# Altium schematic sheet OvertheTOP_Sensors.SchDoc
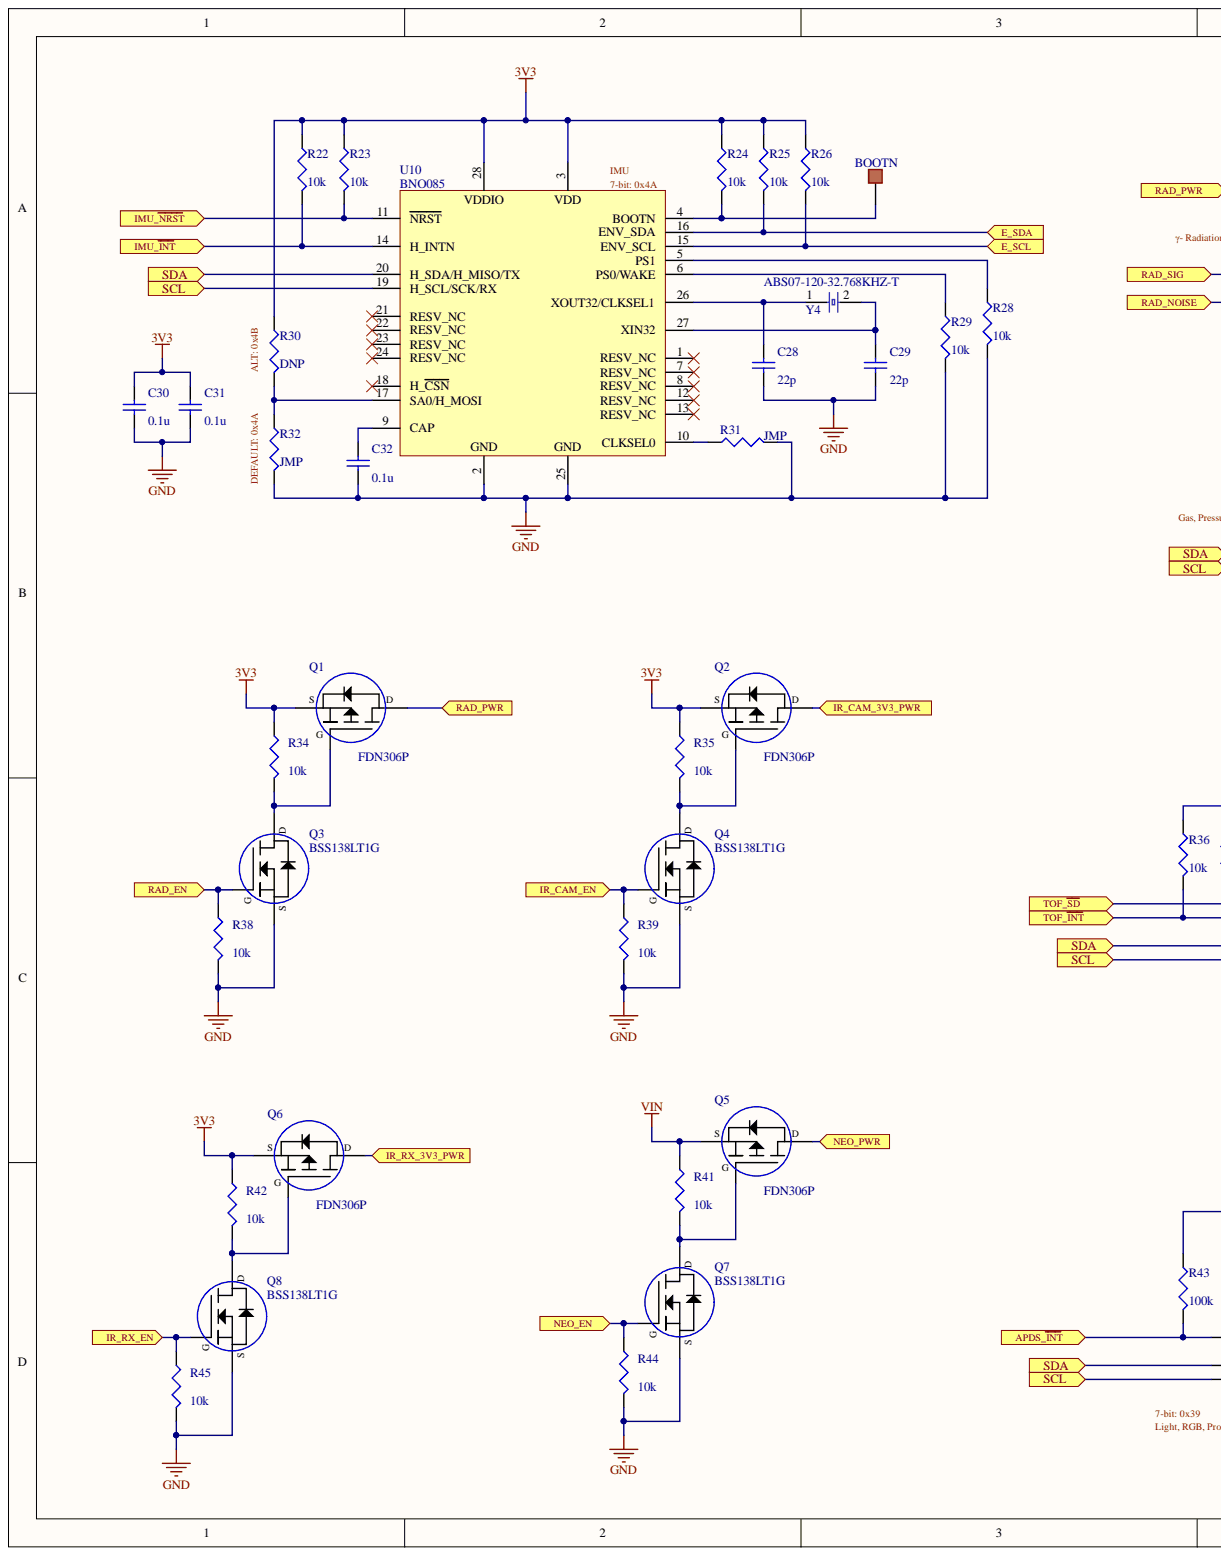
[diagram p0: OvertheTOP_Sensors.SchDoc, left]
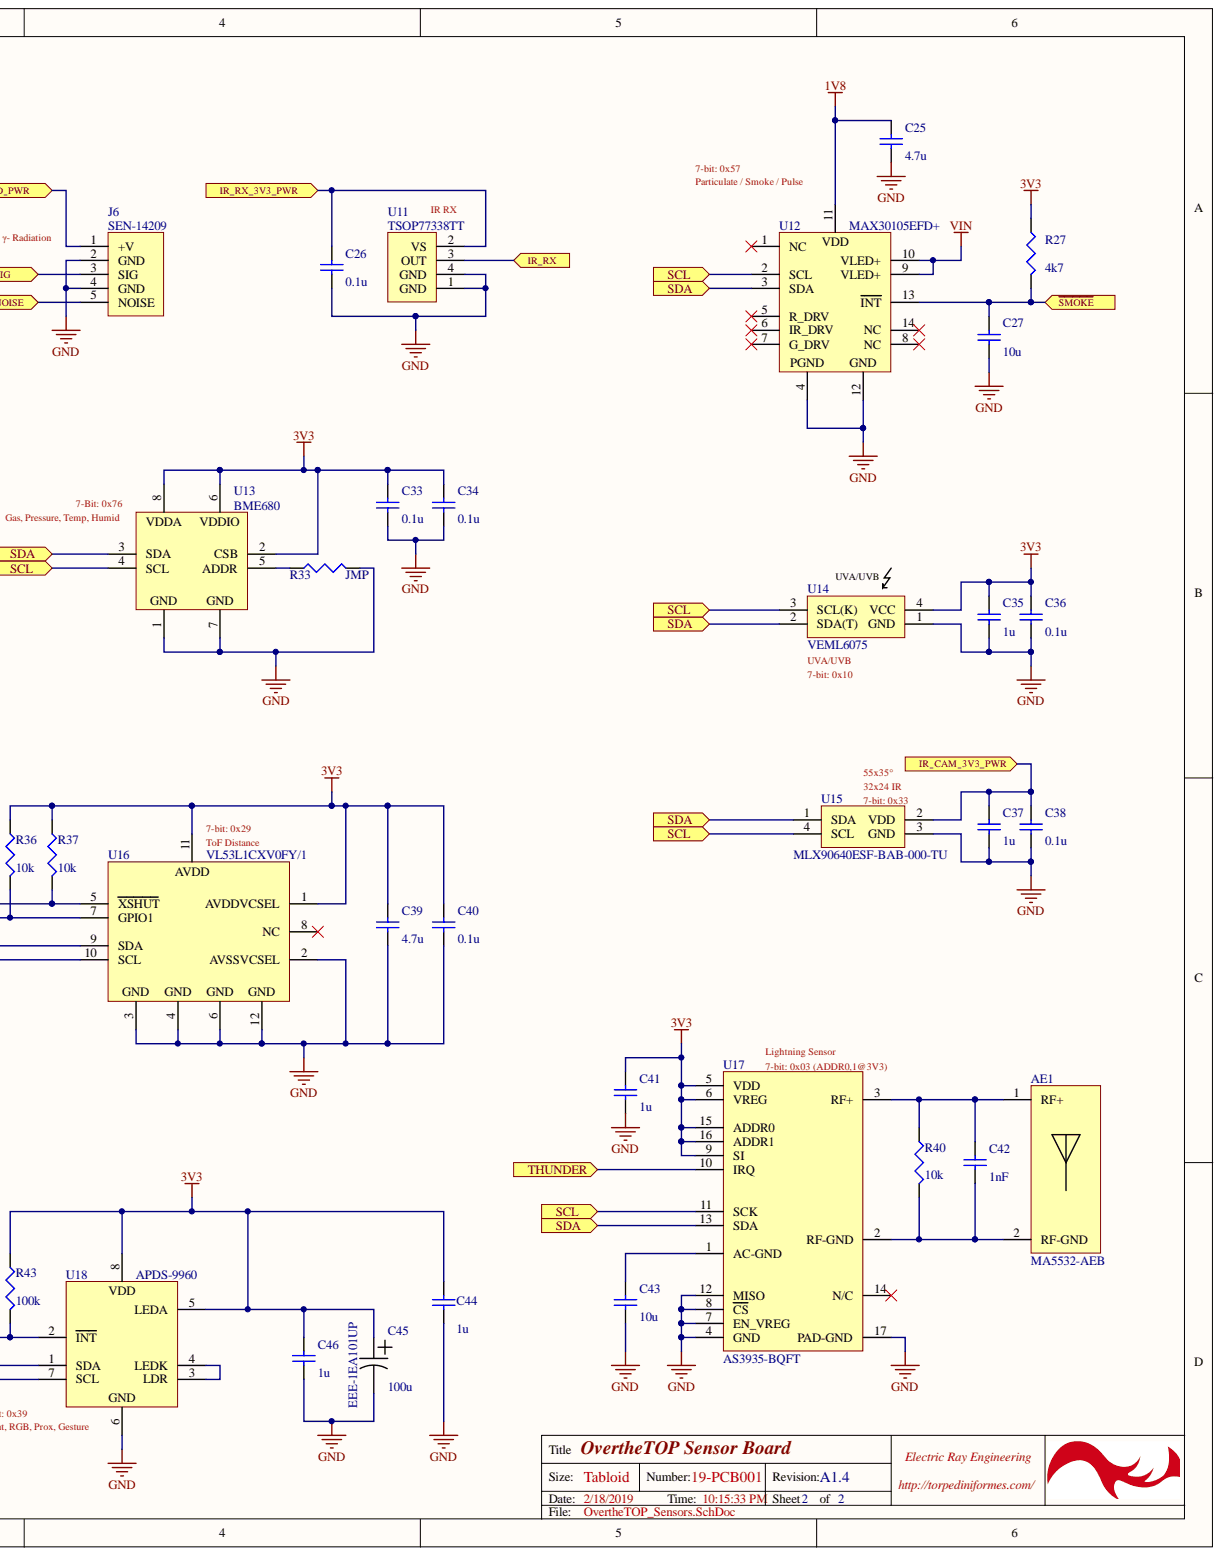
[diagram p1: OvertheTOP_Sensors.SchDoc, right]

## Parts
AE1  MA5532-AEB  [MA5532]
BOOTN  1.5mm  [TP_1.5mm_Square_Bare]  (value not drawn)
C25  value 4.7u  [6-0805_L]  (hidden comment: Cap)
C26  value 0.1u  [6-0805_L]  (hidden comment: Cap)
C27  value 10u  [6-0805_L]  (hidden comment: Cap)
C28  value 22p  [6-0805_L]  (hidden comment: Cap)
C29  value 22p  [6-0805_L]  (hidden comment: Cap)
C30  value 0.1u  [6-0805_L]  (hidden comment: Cap)
C31  value 0.1u  [6-0805_L]  (hidden comment: Cap)
C32  value 0.1u  [6-0805_L]  (hidden comment: Cap)
C33  value 0.1u  [6-0805_L]  (hidden comment: Cap)
C34  value 0.1u  [6-0805_L]  (hidden comment: Cap)
C35  value 1u  [6-0805_L]  (hidden comment: Cap)
C36  value 0.1u  [6-0805_L]  (hidden comment: Cap)
C37  value 1u  [6-0805_L]  (hidden comment: Cap)
C38  value 0.1u  [6-0805_L]  (hidden comment: Cap)
C39  value 4.7u  [6-0805_L]  (hidden comment: Cap)
C40  value 0.1u  [6-0805_L]  (hidden comment: Cap)
C41  value 1u  [6-0805_L]  (hidden comment: Cap)
C42  value 1nF  [0603]  (hidden comment: Cap)
C43  value 10u  [6-0805_L]  (hidden comment: Cap)
C44  value 1u  [6-0805_L]  (hidden comment: Cap)
C45  EEE-1EA101UP  value 100u  [CAP_SIZE_E_PANA]
C46  value 1u  [6-0805_L]  (hidden comment: Cap)
J6  SEN-14209  [SEN-14209]
Q1  FDN306P  [SuperSOT-3]
Q2  FDN306P  [SuperSOT-3]
Q3  BSS138LT1G  [SOT-23]
Q4  BSS138LT1G  [SOT-23]
Q5  FDN306P  [SuperSOT-3]
Q6  FDN306P  [SuperSOT-3]
Q7  BSS138LT1G  [SOT-23]
Q8  BSS138LT1G  [SOT-23]
R22  value 10k  [J1-0603]  (hidden comment: Res3)
R23  value 10k  [J1-0603]  (hidden comment: Res3)
R24  value 10k  [J1-0603]  (hidden comment: Res3)
R25  value 10k  [J1-0603]  (hidden comment: Res3)
R26  value 10k  [J1-0603]  (hidden comment: Res3)
R27  value 4k7  [J1-0603]  (hidden comment: Res3)
R28  value 10k  [J1-0603]  (hidden comment: Res3)
R29  value 10k  [J1-0603]  (hidden comment: Res3)
R30  value DNP  [J1-0603]  (hidden comment: Res3)
R31  value JMP  [J1-0603]  (hidden comment: Res3)
R32  value JMP  [J1-0603]  (hidden comment: Res3)
R33  value JMP  [J1-0603]  (hidden comment: Res3)
R34  value 10k  [J1-0603]  (hidden comment: Res3)
R35  value 10k  [J1-0603]  (hidden comment: Res3)
R36  value 10k  [J1-0603]  (hidden comment: Res3)
R37  value 10k  [J1-0603]  (hidden comment: Res3)
R38  value 10k  [J1-0603]  (hidden comment: Res3)
R39  value 10k  [J1-0603]  (hidden comment: Res3)
R40  value 10k  [J1-0603]  (hidden comment: Res3)
R41  value 10k  [J1-0603]  (hidden comment: Res3)
R42  value 10k  [J1-0603]  (hidden comment: Res3)
R43  value 100k  [J1-0603]  (hidden comment: Res3)
R44  value 10k  [J1-0603]  (hidden comment: Res3)
R45  value 10k  [J1-0603]  (hidden comment: Res3)
U10  BNO085  [BNO085]
U11  TSOP77338TT  [TSOP77338TT]
U12  MAX30105EFD+  [OLGA-14_MAX30105]
U13  BME680  [BME680]
U14  VEML6075  [VEML6075]
U15  MLX90640ESF-BAB-000-TU  [MLX90640]
U16  VL53L1CXV0FY/1  [VL53L1X]
U17  AS3935-BQFT  [AS3935]
U18  APDS-9960  [APDS-9960]
Y4  ABS07-120-32.768KHZ-T  [XTAL_32x15_BSB]

## Ports
APDS_~{INT}, E_SCL, E_SDA, IMU_~{INT}, IMU_~{NRST}, IR_CAM_3V3_PWR, IR_CAM_EN, IR_RX, IR_RX_3V3_PWR, IR_RX_EN, NEO_EN, NEO_PWR, RAD_EN, RAD_NOISE, RAD_PWR, RAD_SIG, SCL, SDA, THUNDER, TOF_~{INT}, TOF_~{SD}, ~{SMOKE}

## Nets
1V8: C25.2 U12.11
3V3: C30.1 C31.1 C33.2 C34.2 C35.2 C36.2 C39.2 C40.2 C41.2 C44.2 C45.P C46.2 Q1.2 Q2.2 Q6.2 R22.1 R23.1 R24.1 R25.1 R26.1 R27.1 R30.1 R34.1 R35.1 R36.1 R37.1 R42.1 R43.1 U10.3 U10.28 U13.2(CSB) U13.6 U13.8 U14.4(VCC) U16.1(AVDDVCSEL) U16.11 U17.5(VDD) U17.6(VREG) U17.9(SI) U17.15(ADDR0) U17.16(ADDR1) U18.5(LEDA) U18.8
GND: C25.1 C26.1 C27.1 C28.2 C29.2 C30.2 C31.2 C32.2 C33.1 C34.1 C35.1 C36.1 C37.1 C38.1 C39.1 C40.1 C41.1 C43.1 C44.1 C45.N C46.1 J6.2(GND) J6.4(GND) Q3.2 Q4.2 Q7.2 Q8.2 R28.2 R29.2 R31.2 R32.2 R33.2 R38.2 R39.2 R44.2 R45.2 U10.2 U10.25 U11.1(GND) U11.4(GND) U12.4 U12.12 U13.1 U13.7 U14.1(GND) U15.3(GND) U16.2(AVSSVCSEL) U16.3 U16.4 U16.6 U16.12 U17.4(GND) U17.7(EN_VREG) U17.8(CS) U17.12(MISO) U17.17(PAD-GND) U18.6
VIN: Q5.2 R41.1 U12.9(VLED+) U12.10(VLED+)
APDS_~{INT}: R43.2 U18.2(INT) ; port APDS_~{INT}
E_SCL: R26.2 U10.15(ENV_SCL) ; port E_SCL
E_SDA: R25.2 U10.16(ENV_SDA) ; port E_SDA
IMU_~{INT}: R22.2 U10.14(H_INTN) ; port IMU_~{INT}
IMU_~{NRST}: R23.2 U10.11(NRST) ; port IMU_~{NRST}
IR_CAM_3V3_PWR: C37.2 C38.2 Q2.3 U15.2(VDD) ; port IR_CAM_3V3_PWR
IR_CAM_EN: Q4.1 R39.1 ; port IR_CAM_EN
IR_RX: U11.3(OUT) ; port IR_RX
IR_RX_3V3_PWR: C26.2 Q6.3 U11.2(VS) ; port IR_RX_3V3_PWR
IR_RX_EN: Q8.1 R45.1 ; port IR_RX_EN
NEO_EN: Q7.1 R44.1 ; port NEO_EN
NEO_PWR: Q5.3 ; port NEO_PWR
RAD_EN: Q3.1 R38.1 ; port RAD_EN
RAD_NOISE: J6.5(NOISE) ; port RAD_NOISE
RAD_PWR: J6.1(+V) Q1.3 ; port RAD_PWR
RAD_SIG: J6.3(SIG) ; port RAD_SIG
~{SMOKE}: C27.2 R27.2 U12.13(INT) ; port ~{SMOKE}
SCL: U10.19(H_SCL/SCK/RX) U12.2(SCL) U13.4(SCL) U14.3(SCL(K)) U15.4(SCL) U16.10(SCL) U17.11(SCK) U18.7(SCL) ; port SCL
SDA: U10.20(H_SDA/H_MISO/TX) U12.3(SDA) U13.3(SDA) U14.2(SDA(T)) U15.1(SDA) U16.9(SDA) U17.13(SDA) U18.1(SDA) ; port SDA
THUNDER: U17.10(IRQ) ; port THUNDER
TOF_~{INT}: R36.2 U16.7(GPIO1) ; port TOF_~{INT}
TOF_~{SD}: R37.2 U16.5(XSHUT) ; port TOF_~{SD}
NetAE1_1: AE1.1 C42.1 R40.1 U17.3(RF+)
NetAE1_2: AE1.2 C42.2 R40.2 U17.2(RF-GND)
NetBOOTN_1: BOOTN.1 R24.2 U10.4(BOOTN)
NetC28_1: C28.1 U10.26(XOUT32/CLKSEL1) Y4.1
NetC29_1: C29.1 U10.27(XIN32) Y4.2
NetC32_1: C32.1 U10.9(CAP)
NetC43_2: C43.2 U17.1(AC-GND)
NetQ1_1: Q1.1 Q3.3 R34.2
NetQ2_1: Q2.1 Q4.3 R35.2
NetQ5_1: Q5.1 Q7.3 R41.2
NetQ6_1: Q6.1 Q8.3 R42.2
NetR28_1: R28.1 U10.5(PS1)
NetR29_1: R29.1 U10.6(PS0/WAKE)
NetR30_2: R30.2 R32.1 U10.17(SA0/H_MOSI)
NetR31_1: R31.1 U10.10(CLKSEL0)
NetR33_1: R33.1 U13.5(ADDR)
NetU18_3: U18.3(LDR) U18.4(LEDK)

Not connected: U10 1(RESV_NC) 7(RESV_NC) 8(RESV_NC) 12(RESV_NC) 13(RESV_NC) 18(H_CSN) 21(RESV_NC) 22(RESV_NC) 23(RESV_NC) 24(RESV_NC); U12 1(NC) 5(R_DRV) 6(IR_DRV) 7(G_DRV) 8(NC) 14(NC); U16 8(NC); U17 14(N/C)

## Library data of the parts
AE1 = MA5532-AE: RFID Transponders; Manufacturer: Coilcraft; Component Kind: Standard; Manufacturer Part Number: MA5532-AEB; Library Name: Electric_Ray_Integrated_01SEPT2018.IntLib
BOOTN = TestPoint_Solderable_1.5mm_Bare: Testpoint Solderable 1.5mm Square; Component Kind: Standard; Library Name: Electric_Ray_Integrated_01SEPT2018.IntLib
C25-C44 C46 = Cap: Capacitor; Component Kind: Standard; LatestRevisionDate: 17-Jul-2002; LatestRevisionNote: Re-released for DXP Platform.; PackageDescription: Radial Cap, Thru-Hole; 2 Leads; 0.3 in Pin Spacing; PackageReference: RAD-0.3; Published: 8-Jun-2000; Simulation: CAP; Library Name: Electric_Ray_Integrated_01SEPT2018.IntLib; Publisher: Altium Limited
C45 = EEE-1EA101UP: CAP ALUM 100UF 20% 25V SMD; Manufacturer: Panasonic Electronic Components; Component Kind: Standard; Manufacturer Part Number: EEE-1EA101UP; Library Name: Electric_Ray_Integrated_01SEPT2018.IntLib
J6 = SEN-14209: Pocket Geiger Radiation Sensor - Type 5; Manufacturer: Sparkfun; Component Kind: Standard; Manufacturer Part Number: SEN-14209; Library Name: Electric_Ray_Integrated_01SEPT2018.IntLib
Q1 Q2 Q5 Q6 = FDN306P: P-Channel -1.8V Specified PowerTrench MOSFET; Manufacturer: On Semiconductor / Fairchild; Component Kind: Standard; Manufacturer Part Number: FDN306P; Library Name: Electric_Ray_Integrated_01SEPT2018.IntLib
Q3 Q4 Q7 Q8 = BSS138LT1G: Power MOSFET, 200mA, 50V, N-Channel, SOT-23; Manufacturer: On Semiconductor; Component Kind: Standard; Manufacturer Part Number: BSS138LT1G; Library Name: Electric_Ray_Integrated_01SEPT2018.IntLib
R22-R45 = Res3: Resistor; Component Kind: Standard; LatestRevisionDate: 29-May-2009; LatestRevisionNote: IPC-7351 Footprint Added.; Library Name: Miscellaneous Devices.IntLib; PackageDescription: Chip Resistor; PackageReference: J1-0603; Published: 8-Jun-2000; Simulation: RESISTOR; Publisher: Altium Limited
U10 = BNO085: IMU ACCEL/GYRO/MAG I2C 32BIT; Manufacturer: Hillcrest Laboratories, Inc.; Component Kind: Standard; Manufacturer Part Number: BNO085; Library Name: ERI_01SEPT2018.SchLib
U11 = TSOP77338TT: MOD IR RCVR 38KHZ TOP VIEW; Manufacturer: Vishay Semiconductor Opto Division; Component Kind: Standard; Manufacturer Part Number: TSOP77338TT; Library Name: Electric_Ray_Integrated_01SEPT2018.IntLib
U12 = MAX30105: SENSOR OPTIC AIR QUAL 14-OESIP; Manufacturer: Maxim Integrated; Component Kind: Standard; Manufacturer Part Number: MAX30105EFD+; Library Name: ERI_01SEPT2018.SchLib
U13 = BME680: SENSOR RH PRESSURE TEMP VOC; Manufacturer: Bosch Sensortec; Component Kind: Standard; Manufacturer Part Number: BME680; Library Name: Electric_Ray_Integrated_01SEPT2018.IntLib
U14 = VEML6075: UVA/UVB SENSOR W/I2C 4-OPLGA; Manufacturer: Vishay Semiconductor Opto Division; Component Kind: Standard; Manufacturer Part Number: VEML6075; Library Name: Electric_Ray_Integrated_01SEPT2018.IntLib
U15 = MLX90640: SENSOR DGTL -40C-85C TO39; Manufacturer: Melexis Technologies NV; Component Kind: Standard; Manufacturer Part Number: MLX90640ESF-BAB-000-TU; Library Name: Electric_Ray_Integrated_01SEPT2018.IntLib
U16 = VL53L1X: OPTICAL LGA12 4.9X2; Manufacturer: STMicroelectronics; Component Kind: Standard; Manufacturer Part Number: VL53L1CXV0FY/1; Library Name: Electric_Ray_Integrated_01SEPT2018.IntLib
U17 = AS3935: IC SENSOR LIGHTNING MLPQ-16; Manufacturer: ams; Component Kind: Standard; Manufacturer Part Number: AS3935-BQFT; Library Name: ERI_01SEPT2018.SchLib
U18 = APDS-9960: SENSOR AMBIENT/PROX DGTL; Manufacturer: Broadcom Limited; Component Kind: Standard; Manufacturer Part Number: APDS-9960; Library Name: ERI_01SEPT2018.SchLib
Y4 = ABS07-120-32.768KHZ-T: CRYSTAL 32.7680KHZ 6PF SMD; Manufacturer: Abracon LLC; Component Kind: Standard; Manufacturer Part Number: ABS07-120-32.768KHZ-T; Library Name: Electric_Ray_Integrated_01SEPT2018.IntLib
